# Altium schematic sheet KS_DAP_WL.SchDoc(KS_DAP_WL)
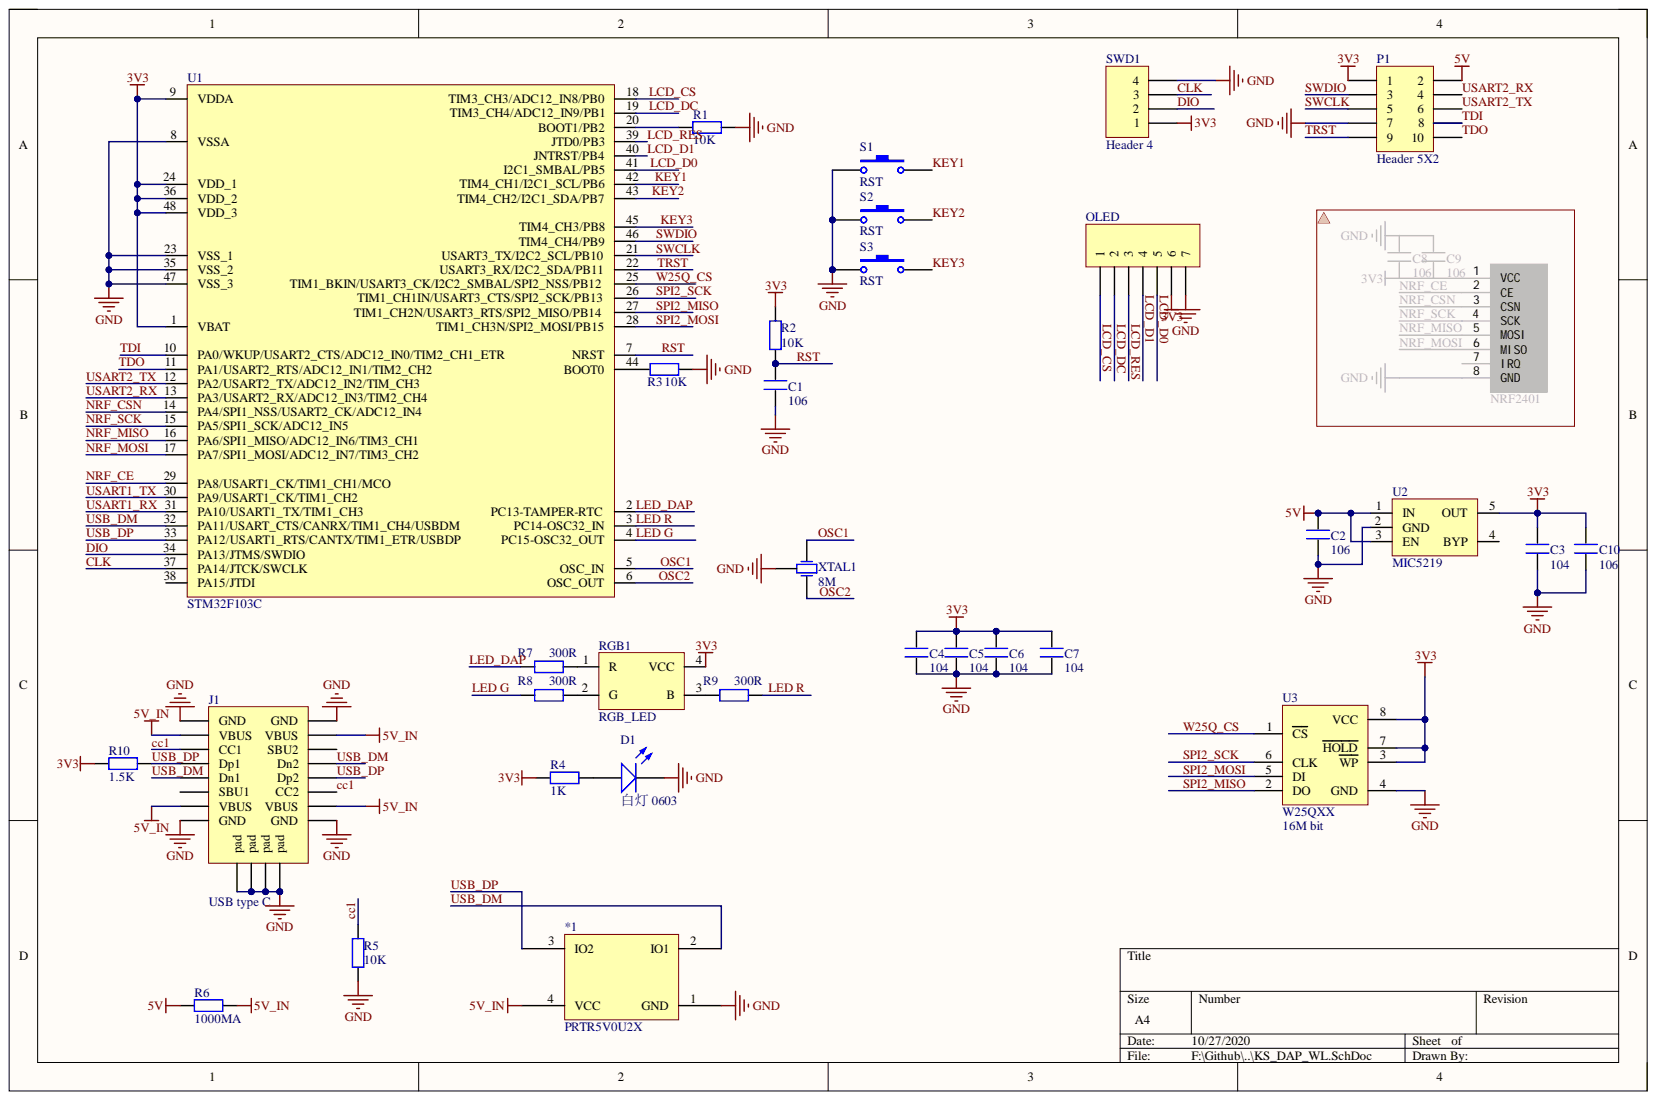
[diagram p0: KS_DAP_WL.SchDoc]

## Parts
*1  PRTR5V0U2X  [LC-SOT-143]
C1  106  [0603_C]
C2  106  [0805_C]
C3  value 104  [0603_C]  (hidden comment: 106)
C4  value 104  [0603_C]  (hidden comment: 106)
C5  value 104  [0603_C]  (hidden comment: 106)
C6  value 104  [0603_C]  (hidden comment: 106)
C7  value 104  [0603_C]  (hidden comment: 106)
C10  106  [0603_C]
D1  白灯 0603  Package: 0603  [0603_LED_S1]
J1  USB type C  [USB Type-C-16Pin_A]
OLED  Header 7  [HDR2.54-LI-7P]  (value not drawn)
P1  Header 5X2  [300S-2*5P 2.54MM_2]
R1  value 10K  [0603_R]  (hidden comment: Res2)
R2  value 10K  [0603_R]  (hidden comment: Res2)
R3  value 10K  [0603_R]  (hidden comment: Res2)
R4  value 1K  [0603_R]  (hidden comment: Res2)
R5  10K  [0603_R]
R6  value 1000MA  [0805_L]  (hidden comment: 1A保险丝)
R7  value 300R  [0603_R]  (hidden comment: 1K)
R8  value 300R  [0603_R]  (hidden comment: 1K)
R9  value 300R  [0603_R]  (hidden comment: 1K)
R10  value 1.5K  [LC-0603_R]  (hidden comment: Res2)
RGB1  RGB_LED  [LED_3528_RGB]
S1  RST  [KEY_1]
S2  RST  [KEY_1]
S3  RST  [KEY_1]
SWD1  Header 4  [HDR1.27-LI-4P]
U1  STM32F103C  [LQFP48 7X7_N]
U2  MIC5219  [SOT23-5N]
U3  W25QXX  Memory: 16M bit  [SOP8W_N]
XTAL1  8M  [XTAL-3215-3P]

## Nets
3V3: C3.2 C4.2 C5.2 C6.2 C7.2 C10.2 OLED.6 P1.1 R2.2 R4.2 R10.1 RGB1.4(VCC) SWD1.1 U1.1(VBAT) U1.9(VDDA) U1.24(VDD_1) U1.36(VDD_2) U1.48(VDD_3) U2.5(OUT) U3.3(WP) U3.7(HOLD) U3.8(VCC)
5V: C2.2 P1.2 R6.1 U2.1(IN) U2.3(EN)
5V_IN: *1.4(VCC) J1.A4(VBUS) J1.A9(VBUS) J1.B4(VBUS) J1.B9(VBUS) R6.2
GND: *1.1(GND) C1.1 C2.1 C3.1 C4.1 C5.1 C6.1 C7.1 C10.1 D1.K J1.0(pad) J1.A1(GND) J1.A12(GND) J1.B1(GND) J1.B12(GND) OLED.7 P1.7 R1.2 R3.2 R5.2 S1.1 S2.1 S3.1 SWD1.4 U1.8(VSSA) U1.23(VSS_1) U1.35(VSS_2) U1.47(VSS_3) U2.2(GND) U3.4(GND) XTAL1.3
cc1: J1.A5(CC1) J1.B5(CC2) R5.1
CLK: SWD1.3 U1.37(PA14/JTCK/SWCLK)
DIO: SWD1.2 U1.34(PA13/JTMS/SWDIO)
KEY1: S1.2 U1.42(TIM4_CH1/I2C1_SCL/PB6)
KEY2: S2.2 U1.43(TIM4_CH2/I2C1_SDA/PB7)
KEY3: S3.2 U1.45(TIM4_CH3/PB8)
LCD_CS: OLED.1 U1.18(TIM3_CH3/ADC12_IN8/PB0)
LCD_D0: OLED.5 U1.41(I2C1_SMBAL/PB5)
LCD_D1: OLED.4 U1.40(JNTRST/PB4)
LCD_DC: OLED.2 U1.19(TIM3_CH4/ADC12_IN9/PB1)
LCD_RES: OLED.3 U1.39(JTD0/PB3)
LED G: R8.1 U1.4(PC15-OSC32_OUT)
LED R: R9.2 U1.3(PC14-OSC32_IN)
LED_DAP: R7.1 U1.2(PC13-TAMPER-RTC)
NRF_CE: U1.29(PA8/USART1_CK/TIM1_CH1/MCO)
NRF_CSN: U1.14(PA4/SPI1_NSS/USART2_CK/ADC12_IN4)
NRF_MISO: U1.16(PA6/SPI1_MISO/ADC12_IN6/TIM3_CH1)
NRF_MOSI: U1.17(PA7/SPI1_MOSI/ADC12_IN7/TIM3_CH2)
NRF_SCK: U1.15(PA5/SPI1_SCK/ADC12_IN5)
OSC1: U1.5(OSC_IN) XTAL1.1
OSC2: U1.6(OSC_OUT) XTAL1.2
RST: C1.2 R2.1 U1.7(NRST)
SPI2_MISO: U1.27(TIM1_CH2N/USART3_RTS/SPI2_MISO/PB14) U3.2(DO)
SPI2_MOSI: U1.28(TIM1_CH3N/SPI2_MOSI/PB15) U3.5(DI)
SPI2_SCK: U1.26(TIM1_CH1IN/USART3_CTS/SPI2_SCK/PB13) U3.6(CLK)
SWCLK: P1.5 U1.21(USART3_TX/I2C2_SCL/PB10)
SWDIO: P1.3 U1.46(TIM4_CH4/PB9)
TDI: P1.8 U1.10(PA0/WKUP/USART2_CTS/ADC12_IN0/TIM2_CH1_ETR)
TDO: P1.10 U1.11(PA1/USART2_RTS/ADC12_IN1/TIM2_CH2)
TRST: P1.9 U1.22(USART3_RX/I2C2_SDA/PB11)
USART1_RX: U1.31(PA10/USART1_TX/TIM1_CH3)
USART1_TX: U1.30(PA9/USART1_CK/TIM1_CH2)
USART2_RX: P1.4 U1.13(PA3/USART2_RX/ADC12_IN3/TIM2_CH4)
USART2_TX: P1.6 U1.12(PA2/USART2_TX/ADC12_IN2/TIM_CH3)
USB_DM: *1.2(IO1) J1.A7(Dn1) J1.B7(Dn2) U1.32(PA11/USART_CTS/CANRX/TIM1_CH4/USBDM)
USB_DP: *1.3(IO2) J1.A6(Dp1) J1.B6(Dp2) R10.2 U1.33(PA12/USART1_RTS/CANTX/TIM1_ETR/USBDP)
W25Q_CS: U1.25(TIM1_BKIN/USART3_CK/I2C2_SMBAL/SPI2_NSS/PB12) U3.1(CS)
NetD1_A: D1.A R4.1
NetR1_1: R1.1 U1.20(BOOT1/PB2)
NetR3_1: R3.1 U1.44(BOOT0)
NetR7_2: R7.2 RGB1.1(R)
NetR8_2: R8.2 RGB1.2(G)
NetR9_1: R9.1 RGB1.3(B)

Not connected: J1 A8(SBU1) B8(SBU2); U1 38(PA15/JTDI); U2 4(BYP)

## Library data of the parts
C1-C7 C10 = C_1: Component Kind: Standard; Library Name: Explorer STM32F407封装库.IntLib
  C1 C2 C10: value: 106
D1 = 0603 白灯: 发光二极管; Component Kind: Standard; ComponentLink1Description: 供应商链接; ComponentLink1URL: http://www.szlcsc.com/product/details_2663.html; Library Name: Miscellaneous Devices LC.IntLib; Supplier: LC; SuppliersPartNumber: C2290
J1 = USB_C: Component Kind: Standard; Library Name: XKZ.SchLib
OLED = Header 7: Header, 7-Pin; Component Kind: Standard; LatestRevisionDate: 17-Jul-2002; LatestRevisionNote: Re-released for DXP Platform.; Signal Integrity: Connector; Library Name: XKZ.SchLib; Publisher: Altium Limited
P1 = Header 5X2: Header, 5-Pin, Dual row; Component Kind: Standard; LatestRevisionDate: 17-Jul-2002; LatestRevisionNote: Re-released for DXP Platform.; Library Name: Miscellaneous Connectors.IntLib; Signal Integrity: Connector; Publisher: Altium Limited
R1-R10 = Res2: Resistor; Component Kind: Standard; LatestRevisionDate: 17-Jul-2002; LatestRevisionNote: Re-released for DXP Platform.; PackageReference: AXIAL-0.4; Published: 8-Jun-2000; Signal Integrity: Res; Simulation: RESISTOR; Publisher: Altium Limited
  R1-R4 R7-R10: Library Name: Miscellaneous Devices.IntLib
  R5: Value: 10K
  R5 R6: Library Name: XKZ.SchLib
RGB1 = RGB_LED: 三色高亮LED; Component Kind: Standard; Library Name: NT-SchLibrary.SchLib
S1-S3 = SW-PB: Switch; Component Kind: Standard; LatestRevisionDate: 17-Jul-2002; LatestRevisionNote: Re-released for DXP Platform.; PackageDescription: Switch; 2 Leads; PackageReference: SPST-2; Published: 24-Mar-1999; Library Name: 自创 (1).SchLib; Publisher: Altium Limited
SWD1 = Header 4: Header, 4-Pin; Component Kind: Standard; LatestRevisionDate: 17-Jul-2002; LatestRevisionNote: Re-released for DXP Platform.; Library Name: Miscellaneous Connectors.IntLib; Signal Integrity: Connector; Publisher: Altium Limited
U2 = MIC5219: 3.3V 降压芯片; Component Kind: Standard; Library Name: NT-SchLibrary.SchLib
U3 = W25QXX: SPI FLASH; Component Kind: Standard; Library Name: fszhang.SchLib
XTAL1 = XTAL1: Component Kind: Standard; Library Name: NT-SchLibrary.SchLib
*1 = PRTR5V0U2X: Component Kind: Standard; Library Name: XKZ.SchLib
U1 = STM32F103C: Component Kind: Standard; Library Name: NT-SchLibrary.SchLib
